# Altium schematic sheet VCM1193L.SchDoc(VCM1193L)
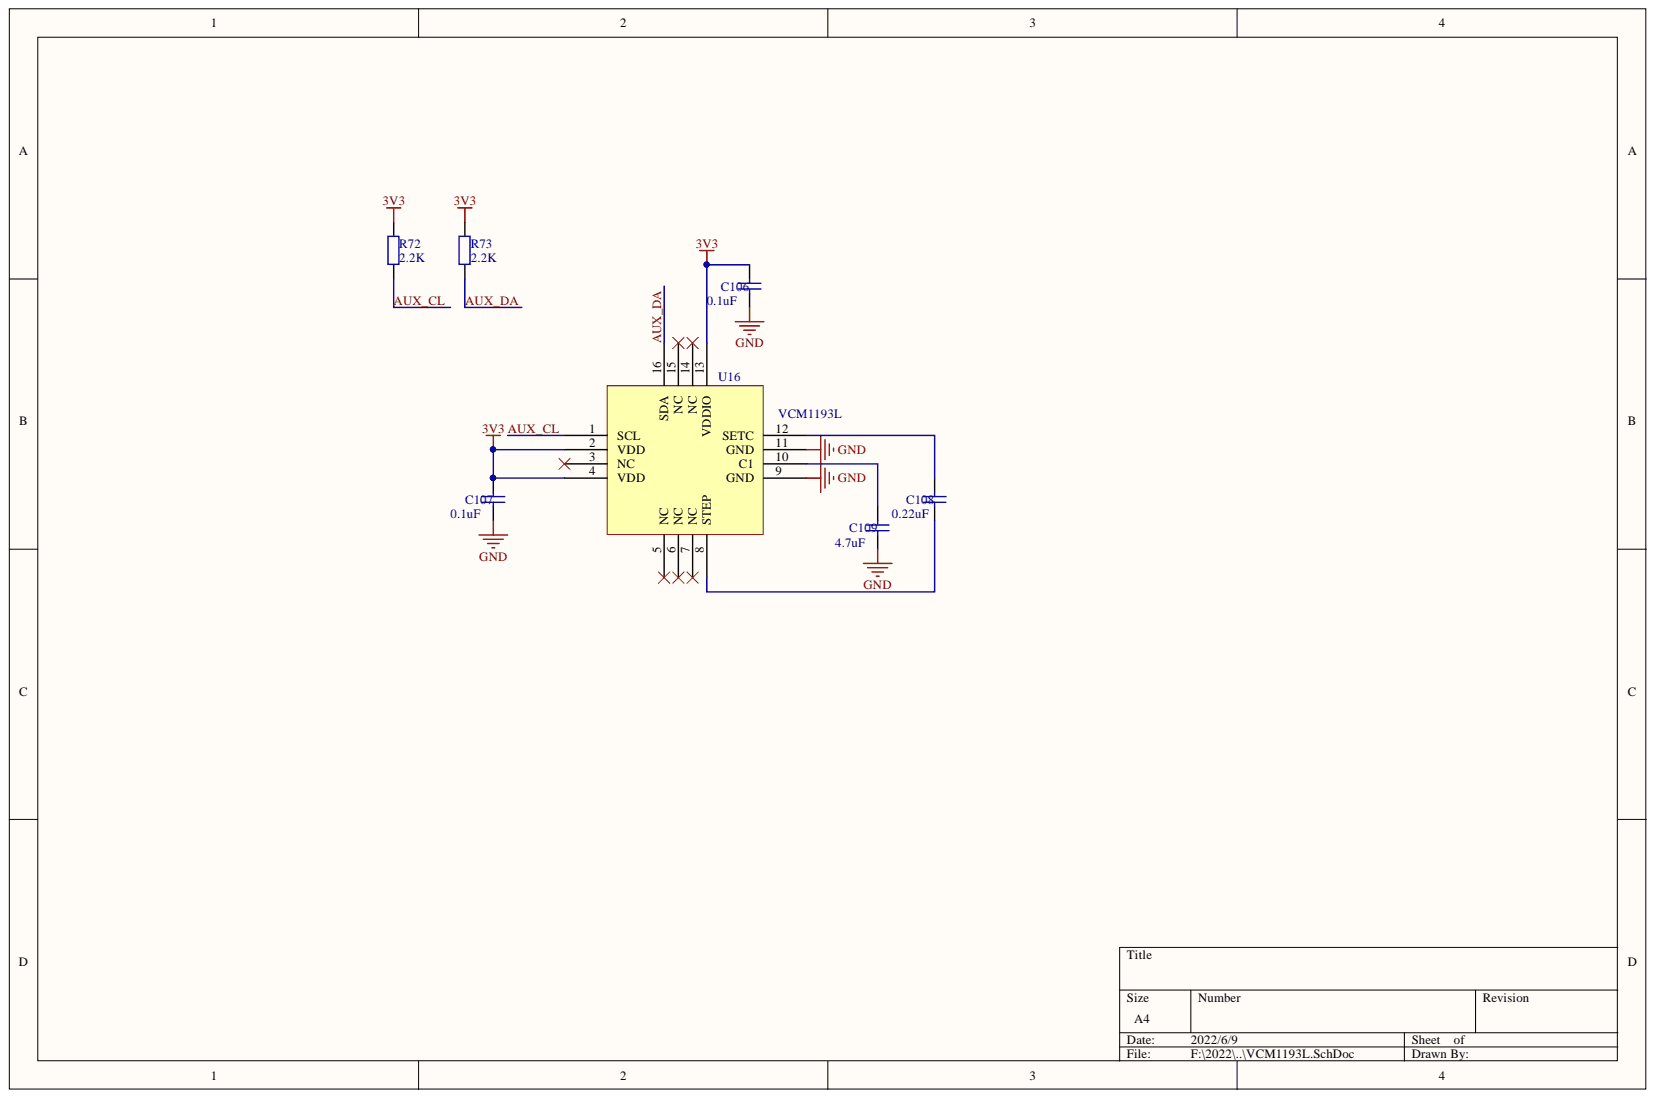
[diagram p0: VCM1193L.SchDoc]

## Parts
C106  0.1uF  [C0603]
C107  0.1uF  [C0603]
C108  0.22uF  [C0603]
C109  4.7uF  [C0603]
R72  2.2K  [R0603]
R73  2.2K  [R0603]
U16  VCM1193L  [VCM1193]

## Nets
3V3: C106.1 C107.1 R72.2 R73.2 U16.2(VDD) U16.4(VDD) U16.13(VDDIO)
GND: C106.2 C107.2 C109.2 U16.9(GND) U16.11(GND)
AUX_CL: R72.1 U16.1(SCL)
AUX_DA: R73.1 U16.16(SDA)
NetC108_1: C108.1 U16.12(SETC)
NetC108_2: C108.2 U16.8(STEP)
NetC109_1: C109.1 U16.10(C1)

Not connected: U16 3(NC) 5(NC) 6(NC) 7(NC) 14(NC) 15(NC)

## Library data of the parts
C106-C109 = Cap: Capacitor; Component Kind: Standard; LatestRevisionDate: 17-Jul-2002; LatestRevisionNote: Re-released for DXP Platform.; Library Name: Miscellaneous Devices.IntLib; PackageDescription: Radial Cap, Thru-Hole; 2 Leads; 0.3 in Pin Spacing; PackageReference: 0603C; Published: 8-Jun-2000; Signal Integrity: Cap; Simulation: CAP; Publisher: Altium Limited
  C106 C107: Value: 0.1uF
  C108: Value: 0.22uF
  C109: Value: 4.7uF
R72 R73 = Res2: Resistor; Component Kind: Standard; LatestRevisionDate: 17-Jul-2002; LatestRevisionNote: Re-released for DXP Platform.; Library Name: Miscellaneous Devices.IntLib; PackageDescription: Axial Device, Thru-Hole; 2 Leads; 0.4 in Pin Spacing; PackageReference: 0603R; Published: 8-Jun-2000; Signal Integrity: Res; Simulation: RESISTOR; Value: 2.2K; Publisher: Altium Limited
U16 = VCM1193L: Component Kind: Standard; Library Name: 芯片类.SchLib
